# Altium schematic sheet 7_HDMI+ETH.schdoc(7_HDMI+ETH)
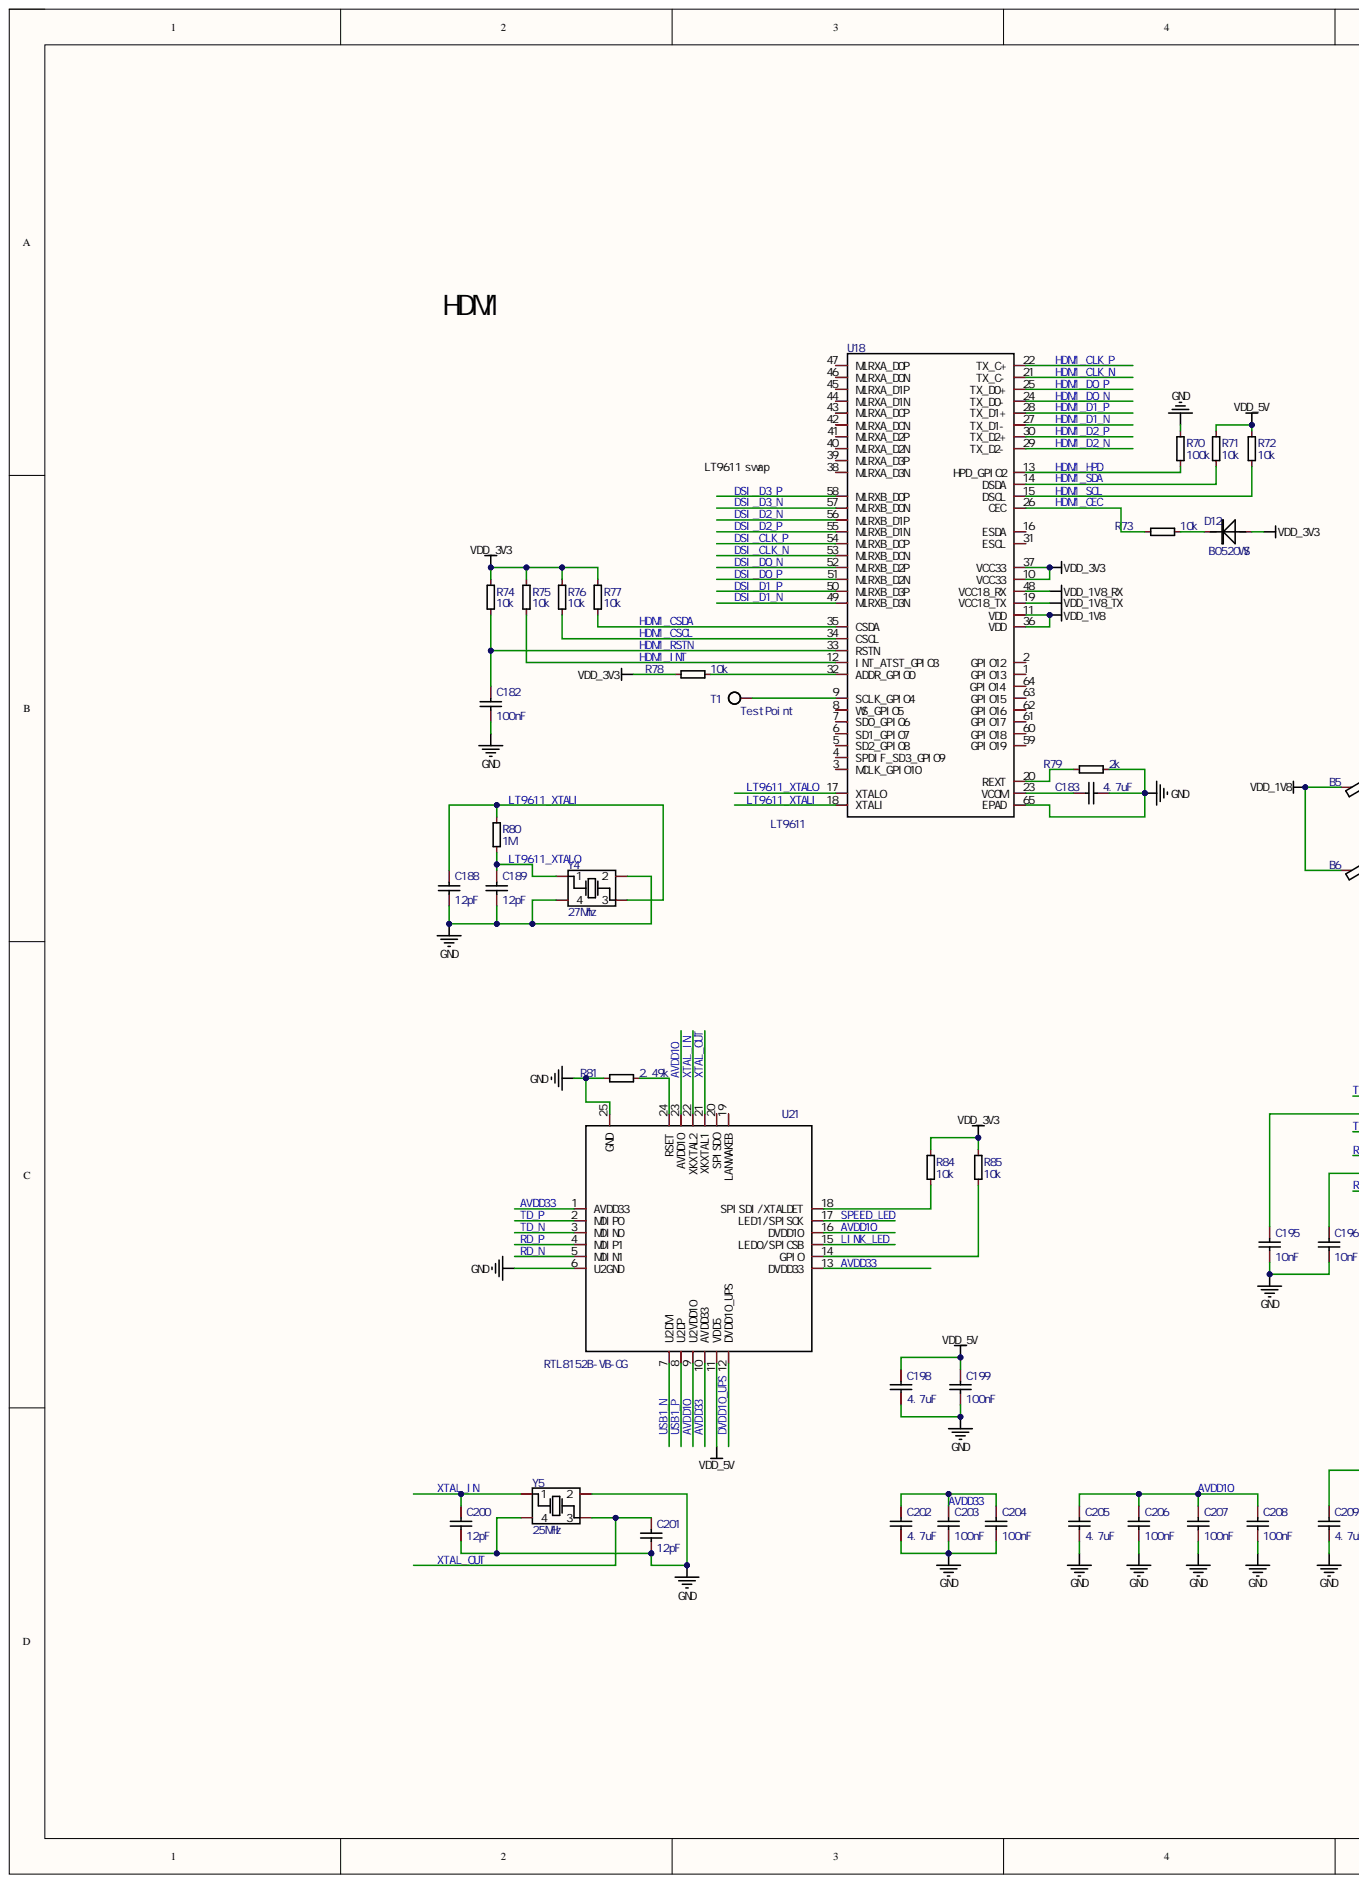
[diagram p0: 7_HDMI+ETH.schdoc, left]
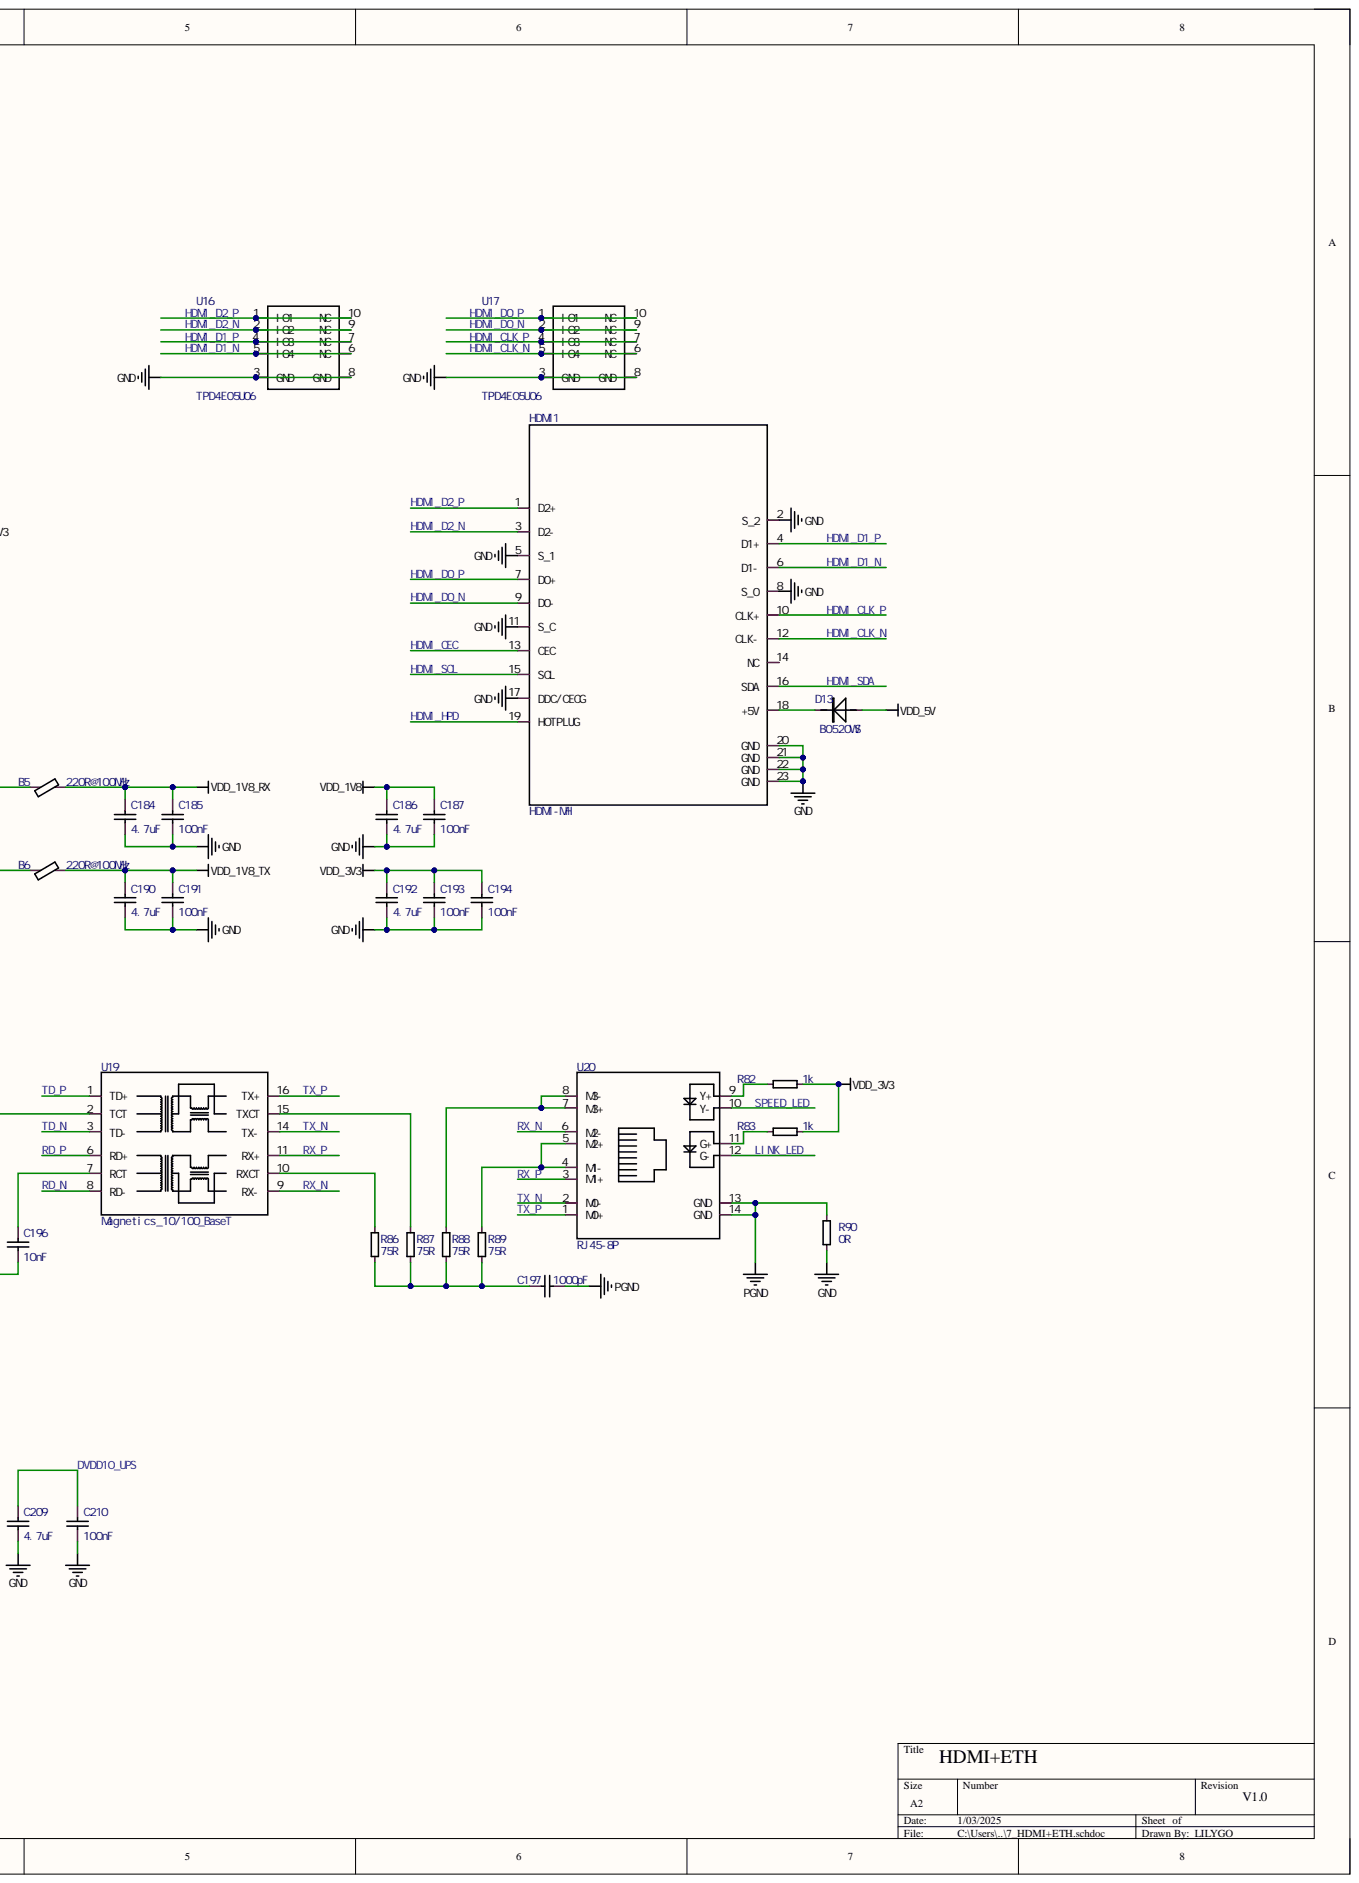
[diagram p1: 7_HDMI+ETH.schdoc, right]

## Parts
B5  220R@100MHz  [r0402]
B6  220R@100MHz  [r0402]
C182  100nF  [c0402]
C183  4.7uF  [c0402]
C184  4.7uF  [c0402]
C185  100nF  [c0402]
C186  4.7uF  [c0402]
C187  100nF  [c0402]
C188  12pF  [c0402]
C189  12pF  [c0402]
C190  4.7uF  [c0402]
C191  100nF  [c0402]
C192  4.7uF  [c0402]
C193  100nF  [c0402]
C194  100nF  [c0402]
C195  10nF  [c0402]
C196  10nF  [c0402]
C197  1000pF  [C1206]
C198  4.7uF  [c0402]
C199  100nF  [c0402]
C200  12pF  [c0402]
C201  12pF  [c0402]
C202  4.7uF  [c0402]
C203  100nF  [c0402]
C204  100nF  [c0402]
C205  4.7uF  [c0402]
C206  100nF  [c0402]
C207  100nF  [c0402]
C208  100nF  [c0402]
C209  4.7uF  [c0402]
C210  100nF  [c0402]
D12  B0520WS  [SOD-523]
D13  B0520WS  [SOD-523]
HDMI1  HDMI-MH  [HDMI]
R70  100k  [r0402]
R71  10k  [r0402]
R72  10k  [r0402]
R73  10k  [r0402]
R74  10k  [r0402]
R75  10k  [r0402]
R76  10k  [r0402]
R77  10k  [r0402]
R78  10k  [r0402]
R79  2k  [r0402]
R80  1M  [r0402]
R81  2.49k  [r0402]
R82  1k  [r0402]
R83  1k  [r0402]
R84  10k  [r0402]
R85  10k  [r0402]
R86  75R  [R0603]
R87  75R  [R0603]
R88  75R  [R0603]
R89  75R  [R0603]
R90  0R  [R0603]
T1  TestPoint  [TestPoint_D30]
U16  TPD4E05U06  [DFN_2510_10]
U17  TPD4E05U06  [DFN_2510_10]
U18  LT9611  [QFN_64_7P5X7P5_0P4]
U19  Magnetics_10/100_BaseT  [MAGNETICS_10/100_BASET]
U20  RJ45-8P  [RJ45_RC01812]
U21  RTL8152B-VB-CG  [QFN_24]
Y4  27Mhz  [XTAL_3225]
Y5  25MHz  [XTAL_3225]

## Nets
GND: C182.2 C183.2 C184.2 C185.2 C186.2 C187.2 C188.2 C189.2 C190.2 C191.2 C192.2 C193.2 C194.2 C195.2 C196.2 C198.2 C199.2 C200.2 C201.2 C202.2 C203.2 C204.2 C205.2 C206.2 C207.2 C208.2 C209.2 C210.2 HDMI1.2(S_2) HDMI1.5(S_1) HDMI1.8(S_0) HDMI1.11(S_C) HDMI1.17(DDC/CECG) HDMI1.20(GND) HDMI1.21(GND) HDMI1.22(GND) HDMI1.23(GND) R70.1 R79.2 R81.1 R90.1 U16.3(GND) U16.8(GND) U17.3(GND) U17.8(GND) U18.65(EPAD) U21.6(U2GND) U21.25(GND) Y4.2 Y4.4 Y5.2 Y5.4
PGND: C197.2 R90.2 U20.13(GND) U20.14(GND)
VDD_1V8: B5.1 B6.1 C186.1 C187.1 U18.11(VDD) U18.36(VDD)
VDD_1V8_RX: B5.2 C184.1 C185.1 U18.48(VCC18_RX)
VDD_1V8_TX: B6.2 C190.1 C191.1 U18.19(VCC18_TX)
VDD_3V3: C192.1 C193.1 C194.1 D12.1 R74.1 R75.1 R76.1 R77.1 R78.1 R82.2 R83.2 R84.1 R85.1 U18.10(VCC33) U18.37(VCC33)
VDD_5V: C198.1 C199.1 D13.1 R71.1 R72.1 U21.11(VDD5)
AVDD10: C205.1 C206.1 C207.1 C208.1 U21.9(U2VDD10) U21.16(DVDD10) U21.23(AVDD10)
AVDD33: C202.1 C203.1 C204.1 U21.1(AVDD33) U21.10(AVDD33) U21.13(DVDD33)
DSI_CLK_N: U18.53(MLRXB_DCN)
DSI_CLK_P: U18.54(MLRXB_DCP)
DSI_D0_N: U18.52(MLRXB_D2P)
DSI_D0_P: U18.51(MLRXB_D2N)
DSI_D1_N: U18.49(MLRXB_D3N)
DSI_D1_P: U18.50(MLRXB_D3P)
DSI_D2_N: U18.56(MLRXB_D1P)
DSI_D2_P: U18.55(MLRXB_D1N)
DSI_D3_N: U18.57(MLRXB_D0N)
DSI_D3_P: U18.58(MLRXB_D0P)
DVDD10_UPS: C209.1 C210.1 U21.12(DVDD10_UPS)
HDMI_CEC: HDMI1.13(CEC) R73.1 U18.26(CEC)
HDMI_CLK_N: HDMI1.12(CLK-) U17.5(IO4) U17.6(NC) U18.21(TX_C-)
HDMI_CLK_P: HDMI1.10(CLK+) U17.4(IO3) U17.7(NC) U18.22(TX_C+)
HDMI_CSCL: R76.2 U18.34(CSCL)
HDMI_CSDA: R77.2 U18.35(CSDA)
HDMI_D0_N: HDMI1.9(D0-) U17.2(IO2) U17.9(NC) U18.24(TX_D0-)
HDMI_D0_P: HDMI1.7(D0+) U17.1(IO1) U17.10(NC) U18.25(TX_D0+)
HDMI_D1_N: HDMI1.6(D1-) U16.5(IO4) U16.6(NC) U18.27(TX_D1-)
HDMI_D1_P: HDMI1.4(D1+) U16.4(IO3) U16.7(NC) U18.28(TX_D1+)
HDMI_D2_N: HDMI1.3(D2-) U16.2(IO2) U16.9(NC) U18.29(TX_D2-)
HDMI_D2_P: HDMI1.1(D2+) U16.1(IO1) U16.10(NC) U18.30(TX_D2+)
HDMI_HPD: HDMI1.19(HOTPLUG) R70.2 U18.13(HPD_GPIO2)
HDMI_INT: R75.2 U18.12(INT_ATST_GPIO3)
HDMI_RSTN: C182.1 R74.2 U18.33(RSTN)
HDMI_SCL: HDMI1.15(SCL) R72.2 U18.15(DSCL)
HDMI_SDA: HDMI1.16(SDA) R71.2 U18.14(DSDA)
LINK_LED: U20.12(G-) U21.15(LED0/SPICSB)
LT9611_XTALI: C188.1 R80.1 U18.18(XTALI) Y4.3
LT9611_XTALO: C189.1 R80.2 U18.17(XTALO) Y4.1
RD_N: U19.8(RD-) U21.5(MDIN1)
RD_P: U19.6(RD+) U21.4(MDIP1)
RX_N: U19.9(RX-) U20.6(M2-)
RX_P: U19.11(RX+) U20.3(M1+)
SPEED_LED: U20.10(Y-) U21.17(LED1/SPISCK)
TD_N: U19.3(TD-) U21.3(MDIN0)
TD_P: U19.1(TD+) U21.2(MDIP0)
TX_N: U19.14(TX-) U20.2(M0-)
TX_P: U19.16(TX+) U20.1(M0+)
USB1_N: U21.7(U2DM)
USB1_P: U21.8(U2DP)
XTAL_IN: C200.1 U21.22(XKXTAL2) Y5.1
XTAL_OUT: C201.1 U21.21(XKXTAL1) Y5.3
NetC183_1: C183.1 U18.23(VCOM)
NetC195_1: C195.1 U19.2(TCT)
NetC196_1: C196.1 U19.7(RCT)
NetC197_1: C197.1 R86.2 R87.2 R88.2 R89.2
NetD12_2: D12.2 R73.2
NetD13_2: D13.2 HDMI1.18(+5V)
NetR78_2: R78.2 U18.32(ADDR_GPIO0)
NetR79_1: R79.1 U18.20(REXT)
NetR81_2: R81.2 U21.24(RSET)
NetR82_1: R82.1 U20.9(Y+)
NetR83_1: R83.1 U20.11(G+)
NetR84_2: R84.2 U21.18(SPISDI/XTALDET)
NetR85_2: R85.2 U21.14(GPIO)
NetR86_1: R86.1 U19.10(RXCT)
NetR87_1: R87.1 U19.15(TXCT)
NetR88_1: R88.1 U20.7(M3+) U20.8(M3-)
NetR89_1: R89.1 U20.4(M1-) U20.5(M2+)
NetT1_TP: T1.TP U18.9(SCLK_GPIO4)

Not connected: HDMI1 14(NC); U18 1(GPIO13) 2(GPIO12) 3(MCLK_GPIO10) 4(SPDIF_SD3_GPIO9) 5(SD2_GPIO8) 6(SD1_GPIO7) 7(SD0_GPIO6) 8(WS_GPIO5) 16(ESDA) 31(ESCL) 38(MLRXA_D3N) 39(MLRXA_D3P) 40(MLRXA_D2N) 41(MLRXA_D2P) 42(MLRXA_DCN) 43(MLRXA_DCP) 44(MLRXA_D1N) 45(MLRXA_D1P) 46(MLRXA_D0N) 47(MLRXA_D0P) 59(GPIO19) 60(GPIO18) 61(GPIO17) 62(GPIO16) 63(GPIO15) 64(GPIO14); U21 19(LANWAKEB) 20(SPISDO)

## Library data of the parts
B5 B6 = BEAD: Add into BOM: yes; Comment_1: 220R@100MHz; Component Kind: Standard; Convert to PCB: yes; Device: BEAD; Symbol: BEAD
C182-C210 = CAP: Add into BOM: yes; Component Kind: Standard; Convert to PCB: yes; Device: CAP; Symbol: CAP
  C182 C185 C187 C191 C193 C194 C199 C203 C204 C206-C208 C210: Comment_1: 100nF
  C183 C184 C186 C190 C192 C198 C202 C205 C209: Comment_1: 4.7uF
  C188 C189 C200 C201: Comment_1: 12pF
  C195 C196: Comment_1: 10nF
  C197: Comment_1: 1000pF
D12 D13 = B0520WS: SOD-323封装 B0520WS; Add into BOM: yes; LC_CODE: C475610; Origin Footprint 2: SMT_DIODE_SOD_123; Symbol: DIODE; Comment_1: B0520WS; Component Kind: Standard; Convert to PCB: yes; Design Item ID: DIODE; Device: B0520WS; Library Name: ZX_MyLib; Origin Footprint 1: SOD-323
HDMI1 = HDMI-MH: HDMI-MH 标准母座连接器; Add into BOM: yes; LC_CODE: C2858275; Comment_1: HDMI-MH; Component Kind: Standard; Convert to PCB: yes; Design Item ID: HDMI-MH; Device: HDMI-MH; Library Name: ZX_MyLib; Origin Footprint: HDMI; Symbol: HDMI-MH
R70-R90 = RES: Add into BOM: yes; Component Kind: Standard; Convert to PCB: yes; Device: RES; Symbol: RES
  R70: Comment_1: 100k
  R71-R78 R84 R85: Comment_1: 10k
  R79: Comment_1: 2k
  R80: Comment_1: 1M
  R81: Comment_1: 2.49k
  R82 R83: Comment_1: 1k
  R86-R89: Comment_1: 75R
  R90: Comment_1: 0R
T1 = TestPoint: Add into BOM: yes; Origin Footprint 2: TestPoint_D40; Origin Footprint 3: TestHole_D60d30; Comment_1: TestPoint; Component Kind: Standard; Convert to PCB: yes; Description: TestPoint; Design Item ID: TestPoint; Device: TestPoint; Library Name: ZX_MyLib; Origin Footprint 1: TestPoint_D30; Symbol: TestPoint
U16 U17 = TPD4E05U06: 防静电IC TPD4E05U06DQAR; Add into BOM: yes; LC_CODE: C587162; Comment_1: TPD4E05U06; Component Kind: Standard; Convert to PCB: yes; Design Item ID: TPD4E05U06; Device: TPD4E05U06; Library Name: ZX_MyLib; Origin Footprint: DFN_2510_10; Symbol: TPD4E05U06
U18 = LT9611: 龙讯 LT9611; Add into BOM: yes; Comment_1: LT9611; Component Kind: Standard; Convert to PCB: yes; Design Item ID: LT9611; Device: LT9611; Library Name: ZX_MyLib; Origin Footprint: QFN_64_7P5x7P5_0P4; Symbol: LT9611
U19 = Magnetics_10/100_BaseT: 10/100 以太网变压器; Add into BOM: yes; LC_CODE: C3003215; Comment_1: Magnetics_10/100_BaseT; Component Kind: Standard; Convert to PCB: yes; Design Item ID: Magnetics_10/100_BaseT; Device: Magnetics_10/100_BaseT; Library Name: ZX_MyLib; Origin Footprint: Magnetics_10/100_BaseT; Symbol: Magnetics_10/100_BaseT
U20 = RJ45-8P: Add into BOM: yes; Comment_1: RJ45-8P; Component Kind: Standard; Convert to PCB: yes; Device: RJ45-8P; Symbol: RJ45-8P
U21 = RTL8152B-VB-CG: Add into BOM: yes; Comment_1: RTL8152B-VB-CG; Component Kind: Standard; Convert to PCB: yes; Description: RTL8152B-VB-CG; Design Item ID: RTL8152B-VB-CG; Device: RTL8152B-VB-CG; Library Name: ZX_MyLib; Origin Footprint: QFN_24; Symbol: RTL8152B-VB-CG
Y4 Y5 = CRYSTAL2: Add into BOM: yes; Component Kind: Standard; Convert to PCB: yes; Device: CRYSTAL2; Symbol: CRYSTAL2
  Y4: Comment_1: 27Mhz
  Y5: Comment_1: 25MHz
